# Altium schematic sheet RC_Transmitter.SchDoc(RC_Transmitter)
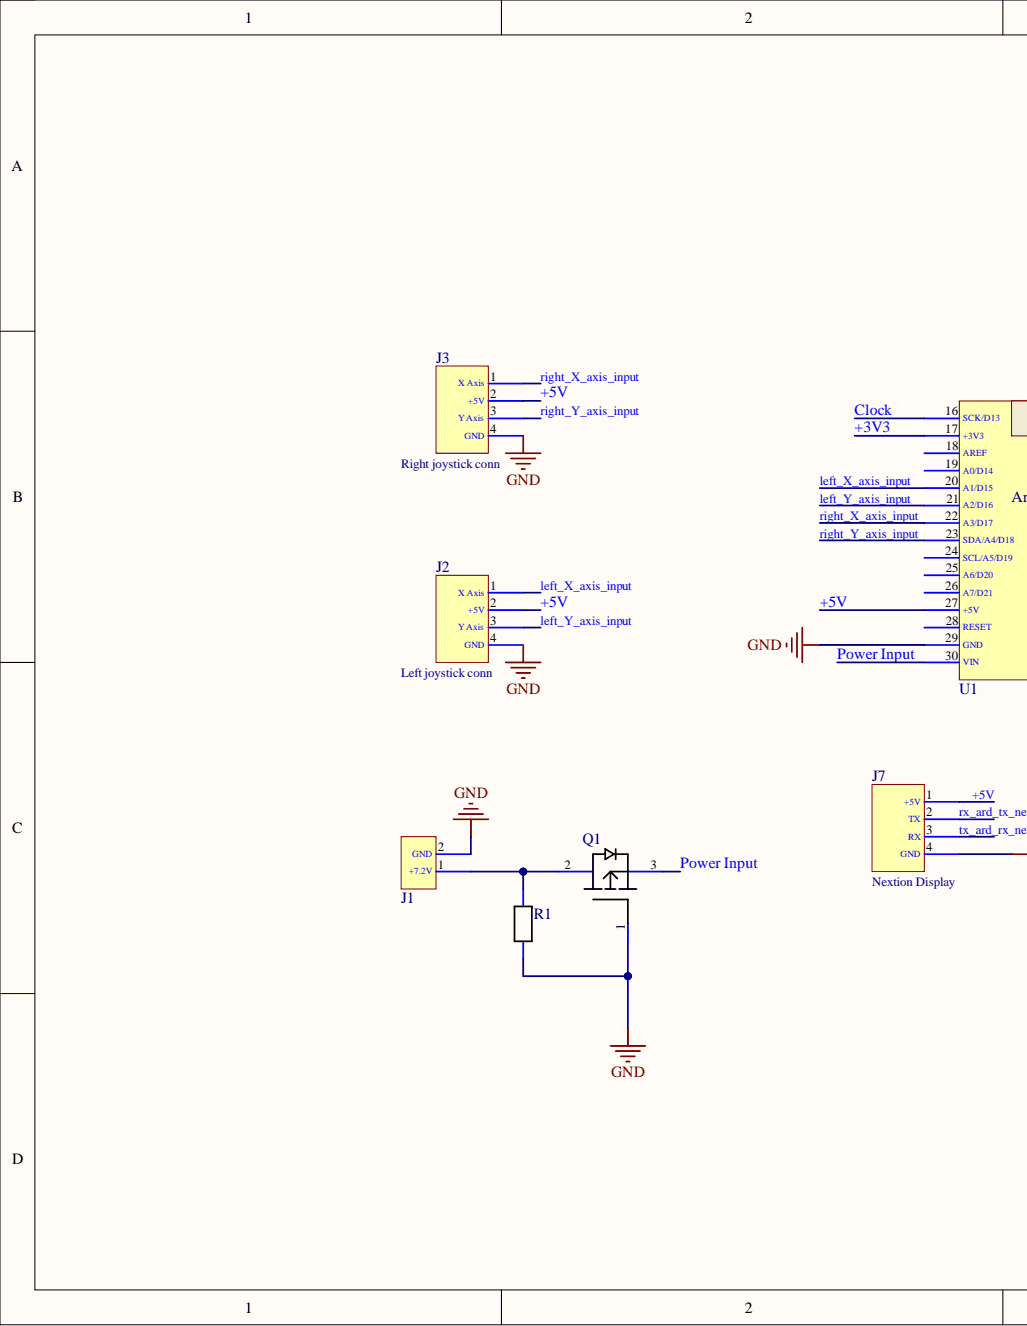
[diagram p0: RC_Transmitter.SchDoc, left]
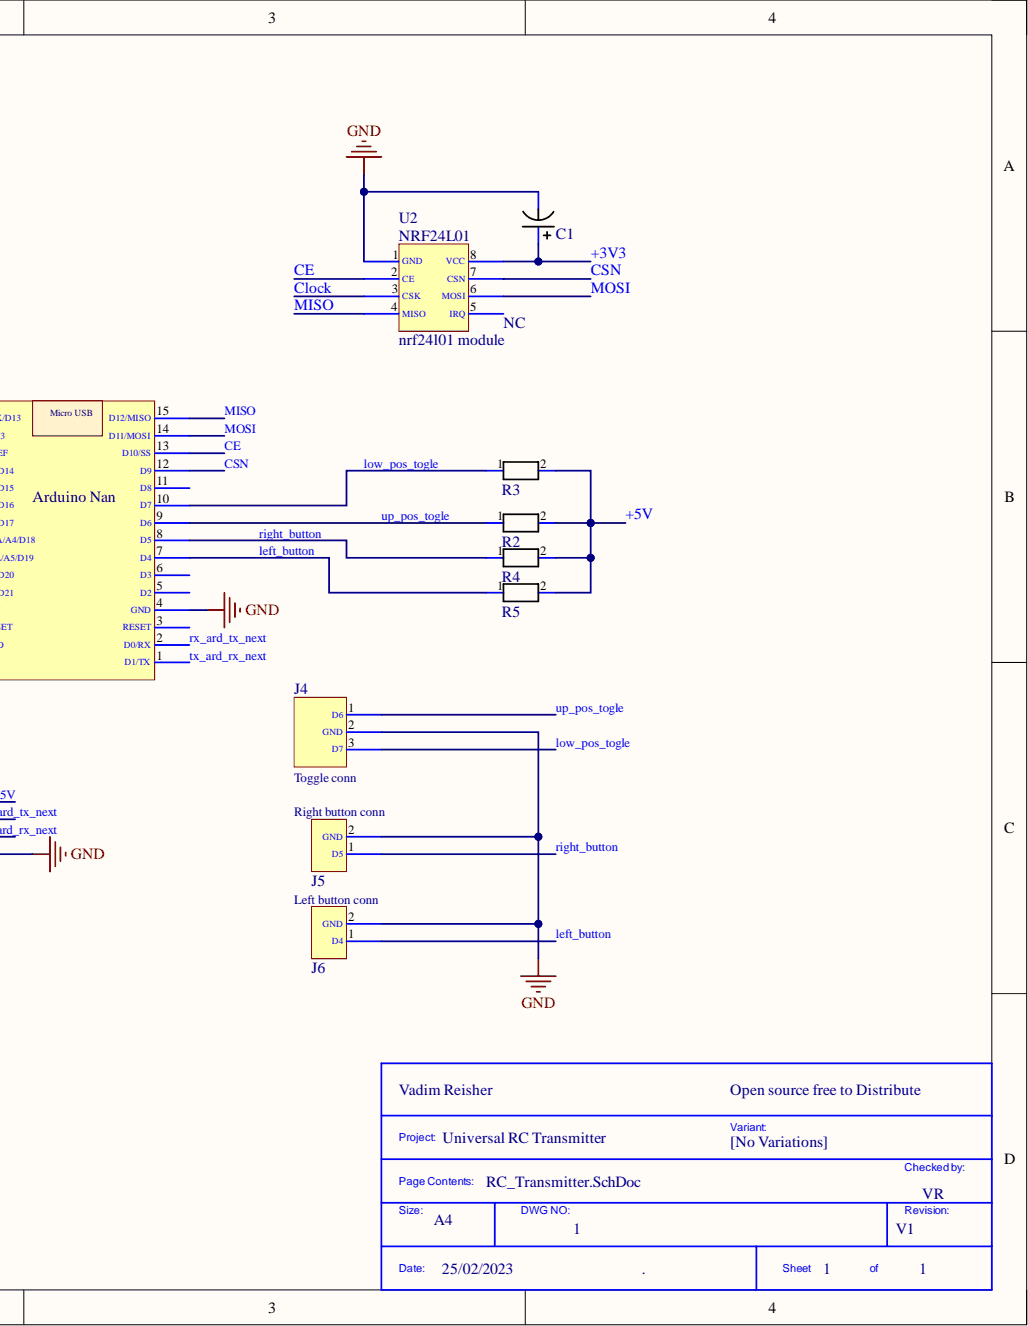
[diagram p1: RC_Transmitter.SchDoc, right]

## Parts
C1  50SVPF10M  [Cap Poly SVPF]  (value not drawn)
J1  S2B-PH-K-S(LF)(SN)  [HDR 2POS JST]  (value not drawn)
J2  S4B-PH-K-S(LF)(SN)  [HDR 4POS JST]  (value not drawn)
J3  S4B-PH-K-S(LF)(SN)  [HDR 4POS JST]  (value not drawn)
J4  S3B-PH-K-S(LF)(SN)  [HDR 3Pos JST]  (value not drawn)
J5  S2B-PH-K-S(LF)(SN)  [HDR 2POS JST]  (value not drawn)
J6  S2B-PH-K-S(LF)(SN)  [HDR 2POS JST]  (value not drawn)
J7  S4B-PH-K-S(LF)(SN)  [HDR 4POS JST]  (value not drawn)
Q1  AO3401A  [SOT23-3]  (value not drawn)
R1  AC0402FR-7W100KL  [R0402 YAGEO]  (value not drawn)
R2  RC2512  [R2512 YAGEO]  (value not drawn)
R3  RC2512  [R2512 YAGEO]  (value not drawn)
R4  RC2512  [R2512 YAGEO]  (value not drawn)
R5  RC2512  [R2512 YAGEO]  (value not drawn)
U1  Arduino Nano  [Arduino nano]  (value not drawn)
U2  nrf24l01 module  [nrf24l01]

## Nets
GND: C1.2 J1.2 J2.4(GND) J3.4(GND) J4.2 J5.2 J6.2 J7.4(GND) Q1.1 R1.2 U1.4(GND) U1.29(GND) U2.1(GND)
+3V3: C1.1 U1.17(+3V3) U2.8(VCC)
+5V: J2.2(+5V) J3.2(+5V) J7.1(+5V) R2.2 R3.2 R4.2 R5.2 U1.27(+5V)
CE: U1.13(D10/SS) U2.2(CE)
Clock: U1.16(SCK/D13) U2.3(CSK)
CSN: U1.12(D9) U2.7(CSN)
left_button: J6.1 R5.1 U1.7(D4)
left_X_axis_input: J2.1(X_Axis) U1.20(A1/D15)
left_Y_axis_input: J2.3(Y_Axis) U1.21(A2/D16)
low_pos_togle: J4.3 R3.1 U1.10(D7)
MISO: U1.15(D12/MISO) U2.4(MISO)
MOSI: U1.14(D11/MOSI) U2.6(MOSI)
Power Input: Q1.3 U1.30(VIN)
right_button: J5.1 R4.1 U1.8(D5)
right_X_axis_input: J3.1(X_Axis) U1.22(A3/D17)
right_Y_axis_input: J3.3(Y_Axis) U1.23(SDA/A4/D18)
rx_ard_tx_next: J7.2(TX) U1.2(D0/RX)
tx_ard_rx_next: J7.3(RX) U1.1(D1/TX)
up_pos_togle: J4.1 R2.1 U1.9(D6)
NetJ1_1: J1.1 Q1.2 R1.1

Not connected: U1 3(RESET) 5(D2) 6(D3) 11(D8) 18(AREF) 19(A0/D14) 24(SCL/A5/D19) 25(A6/D20) 26(A7/D21) 28(RESET); U2 5(IRQ)

## Library data of the parts
C1 = 50SVPF10M: CAP ALUM POLY 10UF 20% 50V SMD; Manufacturer: Panasonic; Dissipation Factor: 12%; ESR (Equivalent Series Resistance): 40mR; Leakage Current: 100uA; Life (Hours): 5000Hour; Ripple Current: 2.5A; Capacitance: 10uF; Case/Package: Radial; Category: Polymer Capacitors; Component Kind: Standard; ComponentLink1Description: https://datasheet.ciiva.com/25761/1734360-25761263.pdf?src-supplier=Farnell; ComponentLink1URL: https://datasheet.ciiva.com/25761/1734360-25761263.pdf?src-supplier=Farnell; ComponentLink2Description: https://datasheet.ciiva.com/24216/svpf-os-262415-24216043.pdf?src-supplier=Mouser; ComponentLink2URL: https://datasheet.ciiva.com/24216/svpf-os-262415-24216043.pdf?src-supplier=Mouser; ComponentLink3Description: https://datasheet.ciiva.com/48542/svpf-eng-ds-48542427.pdf?src-supplier=Distrelec; ComponentLink3URL: https://datasheet.ciiva.com/48542/svpf-eng-ds-48542427.pdf?src-supplier=Distrelec; Construction: Cylindrical; Diameter: 6.3mm; Height: 5.9mm; Height - Seated (Max): 0.236inch; Lead Free: Lead Free; Length: 5.9mm; Library Name: RC_Transmitter_SCHLib.SchLib; Manufacturer Part Number: 50SVPF10M; Max Operating Temperature: 105°C; Min Operating Temperature: -55°C; Mount: Surface Mount; Number of Pins: 6; Package Quantity: 1000; Packaging: Tape and Reel; Pricing 1: 1000=0.61800, 5000=0.60700, 10000=0.60600 (USD); Reach SVHC Compliant: Yes; Ripple Current (AC): 2.5A; RoHS: Yes; RoHS Compliant: Yes; Series: OS-CON, SVPF; Stock 1: 2000; Supplier 1: Farnell; Supplier Part Number 1: 2806073; Termination: SMD/SMT; Tolerance: 20%; Voltage: 50V; Voltage Rating: 50V; Voltage Rating (DC): 50V
J1 J5 J6 = JST 2Pos Header: Connector Header Through Hole, Right Angle 2 position 0.079" (2.00mm); Manufacturer: JST; Connector Type: Male Pin; Contact Resistance: 20mR; Current Rating: 2A; Gender: Male; Insulation Resistance: 1GR; Max Voltage Rating (AC): 100V; Number of Contacts: 2; Pitch: 2mm; Category: Connectors; Color: Natural,White; Component Kind: Standard; ComponentLink1Description: https://datasheet.ciiva.com/11747/0900766b8082f558-11747128.pdf?src-supplier=RSComponents; ComponentLink1URL: https://datasheet.ciiva.com/11747/0900766b8082f558-11747128.pdf?src-supplier=RSComponents; ComponentLink2Description: https://datasheet.ciiva.com/712/eph-712447.pdf?src-supplier=Digi-Key; ComponentLink2URL: https://datasheet.ciiva.com/712/eph-712447.pdf?src-supplier=Digi-Key; ComponentLink3Description: https://datasheet.ciiva.com/16484/s2b-ph-k-slfsn-16484405.pdf?src-supplier=Future+Electronics; ComponentLink3URL: https://datasheet.ciiva.com/16484/s2b-ph-k-slfsn-16484405.pdf?src-supplier=Future+Electronics; ComponentLink4Description: https://datasheet.ciiva.com/26894/getdatasheetpartid-641020-26894599.pdf?src-supplier=Verical; ComponentLink4URL: https://datasheet.ciiva.com/26894/getdatasheetpartid-641020-26894599.pdf?src-supplier=Verical; ComponentLink5Description: https://datasheet.ciiva.com/8052/80564-8052207.pdf?src-supplier=Element14; ComponentLink5URL: https://datasheet.ciiva.com/8052/80564-8052207.pdf?src-supplier=Element14; Contact Material: Brass,Tin,Bronze; Contact Mating Length: 0.13inch; Contact Plating: Tin,Copper; Contact Type: Male Pin; Depth: 7.6mm; Fastening Type: Detent; Flammability Rating: UL94 V-0; Height: 9.4mm; Housing Color: White; Housing Material: Polyamide; Lead Free: Lead Free; Lead Pitch: 2mm; Length: 5.9mm; Library Name: RC_Transmitter_SCHLib.SchLib; Manufacturer Part Number: S2B-PH-K-S(LF)(SN); Max Current Rating: 2A; Max Operating Temperature: 85°C; Max Voltage Rating (DC): 100V; Min Operating Temperature: -25°C; Mount: Through Hole; Number of Pins: 2; Number of Poles: 2; Number of Positions: 2; Number of Rows: 1; Orientation: Right Angle; Packaging: Bulk; Pricing 1: 100=0.11200, 1000=0.08100, 2500=0.07600, 5000=0.07000, 10000=0.06800, 20000=0.06600 (USD); Reach SVHC Compliant: Yes; RoHS: Yes; Series: PH; Stock 1: 983596; Supplier 1: Farnell; Supplier Part Number 1: 9491902; Termination: Solder; Voltage Rating: 100V; Voltage Rating (AC): 100V; Width: 4.5mm; Working Voltage: 100V
J2 J3 J7 = JST 4Pos Header: Connector Header Through Hole, Right Angle 4 position 0.079" (2.00mm); Manufacturer: JST; Connector Type: Male Pin; Contact Resistance: 10mR; Current Rating: 2A; Gender: Male; Insulation Resistance: 1GR; Number of Contacts: 4; Pitch: 2mm; Voltage Rating: 100V; Category: Connectors; Color: Natural,White; Component Kind: Standard; ComponentLink1Description: https://datasheet.ciiva.com/11747/0900766b8082653c-11747869.pdf?src-supplier=RSComponents; ComponentLink1URL: https://datasheet.ciiva.com/11747/0900766b8082653c-11747869.pdf?src-supplier=RSComponents; ComponentLink2Description: https://datasheet.ciiva.com/712/eph-712478.pdf?src-supplier=Digi-Key; ComponentLink2URL: https://datasheet.ciiva.com/712/eph-712478.pdf?src-supplier=Digi-Key; Contact Material: Brass,Tin,Bronze; Contact Mating Length: 0.13inch; Contact Plating: Tin; Contact Type: Male Pin; Fastening Type: Detent; Flammability Rating: UL94 V-0; Height: 9.4mm; Housing Color: Natural; Lead Free: Lead Free; Lead Pitch: 2mm; Length: 9.9mm; Library Name: RC_Transmitter_SCHLib.SchLib; Manufacturer Part Number: S4B-PH-K-S(LF)(SN); Max Operating Temperature: 85°C; Min Operating Temperature: -25°C; Mount: Through Hole; Number of Pins: 4; Number of Poles: 4; Number of Positions: 4; Number of Rows: 1; Orientation: Right Angle; Packaging: Bulk; Pricing 1: 1=0.10300, 400=0.09890, 500=0.09790, 1250=0.09460, 1500=0.09380, 4000=0.09050, 5000=0.08960, 10000=0.08720, 12500=0.08290, 20000=0.08290 (USD); Reach SVHC Compliant: Yes; RoHS: Yes; Series: PH; Stock 1: 69; Supplier 1: Future Electronics; Supplier Part Number 1: 7297922; Termination: Solder; Voltage Rating (AC): 100V; Width: 4.5mm; Working Voltage: 100V
J4 = JST 3Pos Header: Connector Header Through Hole, Right Angle 3 position 0.079" (2.00mm); Manufacturer: JST; Category: Headers and Wire Housings; Component Kind: Standard; ComponentLink1Description: http://www.farnell.com/datasheets/2057211.pdf?src-supplier=Farnell; ComponentLink1URL: http://www.farnell.com/datasheets/2057211.pdf?src-supplier=Farnell; ComponentLink2Description: http://www.farnell.com/datasheets/2057211.pdf?src-supplier=Newark; ComponentLink2URL: http://www.farnell.com/datasheets/2057211.pdf?src-supplier=Newark; ComponentLink3Description: http://www.jst-mfg.com/product/pdf/eng/ePH.pdf?src-supplier=Digi-Key; ComponentLink3URL: http://www.jst-mfg.com/product/pdf/eng/ePH.pdf?src-supplier=Digi-Key; Library Name: RC_Transmitter_SCHLib.SchLib; Manufacturer Part Number: S3B-PH-K-S(LF)(SN); Pricing 1: 1=0.18000, 10=0.15600, 100=0.12020, 500=0.10170, 1000=0.08322, 5000=0.07397, 10000=0.06704 (USD); RoHS: Yes; Stock 1: 257859; Supplier 1: Digi-Key; Supplier Part Number 1: 455-1720-ND
Q1 = AO3401A: Trans Mosfet P-ch 30V 4A 3-PIN SOT-23 T/r; Manufacturer: Alpha & Omega Semiconductor; Input Capacitance: 1.2nF; Max Power Dissipation: 1.4W; Number of Elements: 1; Rds On Max: 44mR; Case/Package: TO-236-3; Category: MOSFETs; Component Kind: Standard; ComponentLink1Description: https://datasheet.ciiva.com/940/ao3401a-940720.pdf?src-supplier=Digi-Key; ComponentLink1URL: https://datasheet.ciiva.com/940/ao3401a-940720.pdf?src-supplier=Digi-Key; ComponentLink2Description: https://datasheet.ciiva.com/26927/getdatasheetpartid-79048-26927888.pdf?src-supplier=Verical; ComponentLink2URL: https://datasheet.ciiva.com/26927/getdatasheetpartid-79048-26927888.pdf?src-supplier=Verical; Continuous Drain Current (ID): 4A; Drain to Source Voltage (Vdss): 30V; Lead Free: Lead Free; Library Name: RC_Transmitter_SCHLib.SchLib; Manufacturer Part Number: AO3401A; Max Operating Temperature: 150°C; Min Operating Temperature: -55°C; Mount: Surface Mount; Number of Pins: 3; Packaging: Tape and Reel; Power Dissipation: 1.4W; Pricing 1: 3000=0.10420, 6000=0.09740 (USD); Radiation Hardening: No; RoHS: Yes; RoHS Compliant: Yes; Series: -; Stock 1: 75000; Supplier 1: Arrow Electronics; Supplier Part Number 1: AO3401A
R1 = R0402: 100 kOhms ±1% 0.125W, 1/8W Chip Resistor 0402 (1005 Metric) Automotive AEC-Q200 Thick Film; Manufacturer: Yageo; Category: Chip SMD Resistors; Component Kind: Standard; Library Name: RC_Transmitter_SCHLib.SchLib; Manufacturer Part Number: AC0402FR-7W100KL; Pricing 1: 10=0.02400, 100=0.01000, 500=0.00500, 2500=0.00400, 5000=0.00300, 10000=0.00200, 50000=0.00200 (USD); RoHS: Yes; Stock 1: 44950; Supplier 1: Farnell; Supplier Part Number 1: 3951313
R2-R5 = RC2512: 220 Ohms ±5% 1W Chip Resistor 2512 (6432 Metric) Moisture Resistant Thick Film; Manufacturer: Yageo; Manufacturer Part Number: RC2512JK-07220RL; Resistance: 220R; Temperature Coefficient: 100ppm/°C; Voltage Rating: 200V; Case Code (Imperial): 2512; Case Code (Metric): 6432; Case/Package: 2512; Category: Chip SMD Resistors; Component Kind: Standard; ComponentLink1Description: https://datasheet.ciiva.com/12575/pyu-rc2512-51-rohs-l-4-12575849.pdf?src-supplier=Mouser; ComponentLink1URL: https://datasheet.ciiva.com/12575/pyu-rc2512-51-rohs-l-4-12575849.pdf?src-supplier=Mouser; ComponentLink2Description: https://datasheet.ciiva.com/17420/pyu-rc2512-51-rohs-l-4-17420683.pdf?src-supplier=Future+Electronics; ComponentLink2URL: https://datasheet.ciiva.com/17420/pyu-rc2512-51-rohs-l-4-17420683.pdf?src-supplier=Future+Electronics; Construction: Rectangular; Depth: 3.1mm; Features: Moisture Resistant; Height: 0.026inch; Lead Free: Lead Free; Length: 0.25inch; Library Name: RC_Transmitter_SCHLib.SchLib; Max Operating Temperature: 155°C; Max Power Dissipation: 1W; Military Standard: Not; Min Operating Temperature: -55°C; Number of Terminations: 2; Packaging: Tape and Reel; Power Rating: 1W; Pricing 1: 1=0.27030, 10=0.22300, 25=0.20630, 100=0.09580, 250=0.09140, 500=0.09050, 1000=0.05870, 3000=0.03120 (USD); Resistance Tolerance: 5%; Resistor Type: Thick Film; RoHS: Yes; RoHS Compliant: Yes; Series: RC; Size Code: 2512; Stock 1: 5700; Supplier 1: Arrow Electronics; Supplier Part Number 1: RC2512JK-07220RL; Termination: SMD/SMT; Tolerance: 5%; Width: 3.1mm
U1 = Arduino Nano: Arduino Nano Module; Component Kind: Standard; Library Name: RC_Transmitter_SCHLib.SchLib
U2 = nrf24l01 module: nrf24l01 module. 2.4Ghz Transmitter; Component Kind: Standard; Library Name: RC_Transmitter_SCHLib.SchLib
